# Altium schematic sheet Power_supply.SchDoc
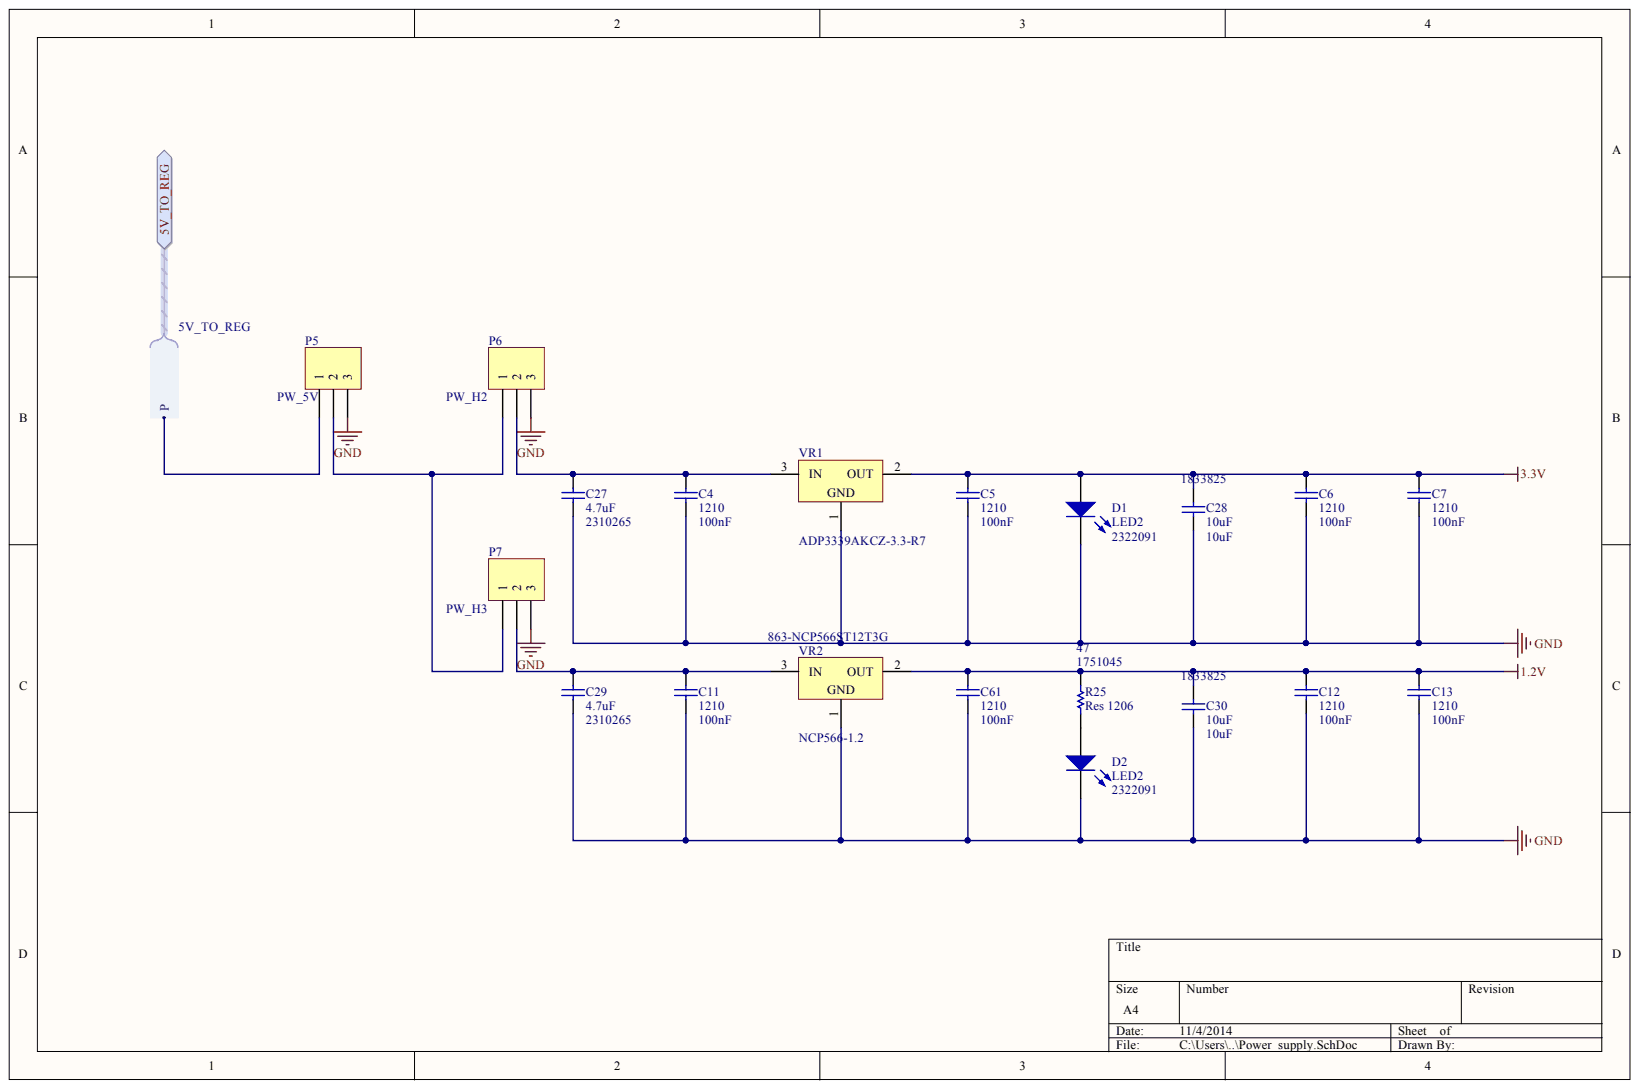
[diagram p0: Power_supply.SchDoc]

## Parts
C4  1210  value 100nF  [CAPC1210(3225)105_L]
C5  1210  value 100nF  [CAPC1210(3225)105_L]
C6  1210  value 100nF  [CAPC1210(3225)105_L]
C7  1210  value 100nF  [CAPC1210(3225)105_L]
C11  1210  value 100nF  [CAPC1210(3225)105_L]
C12  1210  value 100nF  [CAPC1210(3225)105_L]
C13  1210  value 100nF  [CAPC1210(3225)105_L]
C27  4.7uF  Supplier Part Number 1: 2310265  [C1206]
C28  10uF  Supplier Part Number 1: 1833825  [C1206]
C29  4.7uF  Supplier Part Number 1: 2310265  [C1206]
C30  10uF  Supplier Part Number 1: 1833825  [C1206]
C61  1210  value 100nF  [CAPC1210(3225)105_L]
D1  LED2  Supplier Part Number 1: 2322091  [C1206]
D2  LED2  Supplier Part Number 1: 2322091  [C1206]
P5  PW_5V  [HDR1X3]
P6  PW_H2  [HDR1X3]
P7  PW_H3  [HDR1X3]
R25  Res 1206  Case-EIA: 1206  Supplier Part Number 1: 1751045  [RESC1206(3216)_L]
VR1  ADP3339AKCZ-3.3-R7  [ADI-KC-3_M]
VR2  NCP566-1.2  Supplier Part Number 1: 863-NCP566ST12T3G  [SOT223_N]

## Ports
5V_TO_REG, 5V_TO_REG.P

## Nets
1.2V: C12.2 C13.2 C30.2 C61.2 R25.1 VR2.2
3.3V: C5.2 C6.2 C7.2 C28.2 D1.1 VR1.2
GND: C4.1 C5.1 C6.1 C7.1 C11.1 C12.1 C13.1 C27.1 C28.1 C29.1 C30.1 C61.1 D1.2 D2.2 P5.3 P6.3 P7.3 VR1.1 VR2.1
5V_TO_REG: P5.1 ; port 5V_TO_REG
NetC4_2: C4.2 C27.2 P6.2 VR1.3
NetC11_2: C11.2 C29.2 P7.2 VR2.3
NetD2_1: D2.1 R25.2
NetP5_2: P5.2 P6.1 P7.1

## Library data of the parts
C4-C7 C11-C13 C61 = CMP-1038-01663-1: CAP 100nF 100V ±5% 1210 (3225 Metric) Thickness 1.05mm SMD; Case-Metric: 3225; Case-EIA: 1210; Component Kind: Standard; Library Name: Altium Content Vault; Max Thickness: 1.05 mm; Rated Voltage: 100 V; Technology: SMT; Tolerance: ±5%
C27 C29 = Cap Semi: Capacitor (Semiconductor SIM Model); Manufacturer: AVX; Manufacturer Part Number: 12061Z475KAT2A; Capacitance: 4.7; Capacitance Tolerance: ± 10%; Capacitor Case Style: 1206 [3216 Metric]; Capacitor Terminals: SMD<!--FANDB--><div class="features-and-benefits-container"></div>; Dielectric Characteristic: X7; SVHC: No SVHC (16-Jun-2014); Value: 100pF; Voltage Rating: 100; Capacitance Tolerance ±: ± 10%; Ceramic Capacitor Case: 1206 [3216 Metric]; Component Kind: Standard; ComponentLink1Description: http://www.farnell.com/datasheets/1789384.pdf; ComponentLink1URL: http://www.farnell.com/datasheets/1789384.pdf; LatestRevisionDate: 29-May-2009; LatestRevisionNote: IPC-7351 Footprint Added.; Library Name: Miscellaneous Devices.IntLib; MSL: MSL 1 - Unlimited; Operating Temperature Max: 125; Operating Temperature Min: -55; PackageDescription: Chip Capacitor; PackageReference: C1206; Packaging: Cut Tape; Pricing 1: 1=6,85, 50=6,2, 100=4,8, 1000=3,85, 2000=2,45 (EUR); Product Range: -; Published: 8-Jun-2000; RoHS: YES; Signal Integrity: Cap Semi; Simulation: CAP; Stock 1: 213; Supplier 1: Farnell; Publisher: Altium Limited
C28 C30 = Cap Semi: Capacitor (Semiconductor SIM Model); Manufacturer: AVX; Manufacturer Part Number: 12063D106KAT2A; Capacitance: 10; Capacitance Tolerance: ± 10%; Capacitor Case Style: 1206 [3216 Metric]; Capacitor Terminals: SMD; Dielectric Characteristic: X5; SVHC: No SVHC (16-Dec-2013); Voltage Rating: 25; Capacitance Tolerance ±: ± 10%; Ceramic Capacitor Case: 1206 [3216 Metric]; Component Kind: Standard; ComponentLink1Description: http://www.farnell.com/datasheets/1796776.pdf; ComponentLink1URL: http://www.farnell.com/datasheets/1796776.pdf; LatestRevisionDate: 29-May-2009; LatestRevisionNote: IPC-7351 Footprint Added.; Library Name: Miscellaneous Devices.IntLib; MSL: MSL 1 - Unlimited; Operating Temperature Max: 85; Operating Temperature Min: -55; Operating Temperature Range: -55°C to +85; PackageDescription: Chip Capacitor; PackageReference: C1206; Packaging: Cut Tape; Pricing 1: 10=3.58, 100=2.42, 500=1.21, 1000=1, 2000=0.8 (EUR); Product Range: -; Published: 8-Jun-2000; RoHS: YES; Series: Hi-Cap MLCC<!--FANDB--><div class="features-and-benefits-container"></div>; Signal Integrity: Cap Semi; Simulation: CAP; Stock 1: 16889; Supplier 1: Farnell; Value: 10uF; Publisher: Altium Limited
D1 D2 = LED2: Typical RED, GREEN, YELLOW, AMBER GaAs LED; Manufacturer: WURTH ELEKTRONIK; Manufacturer Part Number: 156120GS75300; Component Kind: Standard; ComponentLink1Description: http://katalog.we-online.de/led/datasheet/156120GS75300.pdf; ComponentLink1URL: http://katalog.we-online.de/led/datasheet/156120GS75300.pdf; LatestRevisionDate: 17-Jul-2002; LatestRevisionNote: Re-released for DXP Platform.; Library Name: Miscellaneous Devices.IntLib; PackageDescription: SM LED; 2 Flat Leads; PackageReference: 3.2X1.6X1.1; PackageVersion: Feb-2002; Pricing 1: 1=5,3, 50=4,9, 100=4,6, 500=3,65, 2000=3,3, 5000=2,95, 5000=2,65, 30000=2,4 (EUR); Published: 8-Jun-2000; RoHS: YES; Simulation: LED2; Stock 1: 15358; Supplier 1: Farnell; Publisher: Altium Limited
P5-P7 = Header 3: Header, 3-Pin; Component Kind: Standard; LatestRevisionDate: 17-Jul-2002; LatestRevisionNote: Re-released for DXP Platform.; Library Name: Miscellaneous Connectors.IntLib; Signal Integrity: Connector; Publisher: Altium Limited
R25 = CMP-1014-00056-1: 105R 0.25W 5% 1206 (3216 Metric)  SMD; Manufacturer: PANASONIC; Manufacturer Part Number: ERJB2AF470V; Case-Metric: 3216; External Depth: 1.6; External Length / Height: 0.65; External Width: 3.2; No. of Pins: 2; Overload Voltage: 400; Power Rating: 0.5; Product Range: PANASONIC - ERJ Wide Terminal Series; Reel Quantity: 5000; Resistance: 47; Resistance Tolerance: ± 1%; Resistor Case Style: 1206 Wide; Resistor Element Material: Thick Film; SVHC: No SVHC (16-Dec-2013); Temperature Coefficient: ± 100; Voltage Rating: 200; Component Kind: Standard; ComponentLink1Description: http://www.farnell.com/datasheets/436795.pdf; ComponentLink1URL: http://www.farnell.com/datasheets/436795.pdf; ComponentLink2Description: http://www.farnell.com/datasheets/1718548.pdf; ComponentLink2URL: http://www.farnell.com/datasheets/1718548.pdf; ComponentLink3Description: http://www.farnell.com/cad/1697905.zip; ComponentLink3URL: http://www.farnell.com/cad/1697905.zip; Library Name: Altium Content Vault; MSL: -; Operating Temperature Max: 155; Operating Temperature Min: -55; Operating Temperature Range: -55°C to +155; Packaging: Cut Tape; Power: 0.25 W; Pricing 1: 5=1,83, 50=1,71, 100=1,61, 500=1,52, 5000=1,44 (EUR); Resistor Mounting: SMD; RoHS: YES; Series: ERJ<!--FANDB--><div class="features-and-benefits-container"></div>; Stock 1: 185; Supplier 1: Farnell; Technology: SMT; Tolerance: 5 %; Value: 105R
VR1 = CMP-0786-00156-1: High Accuracy, Ultralow IQ, 1.5 A, anyCAP Low Dropout Regulator, 2.8 to 6 V Vin, 3.3 V Vout, Industrial, 3-pin SOT223 (KC-3), Reel; Manufacturer: Analog Devices; Iout max: 1.5A; Preset Vout Values (V): 3.3; Supply Current IGND @ Small Load: 130uA; Vin (max) (V): 6; Vin (min) (V): 2.8; Code_JEDEC: TO-261-AA; Component Kind: Standard; ComponentLink1Description: Manufacturer URL; ComponentLink1URL: http://www.analog.com/en/index.html; ComponentLink2Description: Datasheet; ComponentLink2URL: http://www.analog.com/static/imported-files/data_sheets/ADP3339.pdf; ComponentLink3Description: Package Specification; ComponentLink3URL: http:/www.analog.com/static/imported-files/packages/PKG_PDF/SOT_223(KC)/KC_3.pdf; ComponentLink4Description: URL Link1; ComponentLink4URL: Product URL:http://www.analog.com/en/ADP3339/productSearch.html; DatasheetVersion: Rev. C; Library Name: Altium Content Vault; Mounting Technology: Surface Mount; PackageDescription: 3-Pin Small Outline Transistor Package, Body 3.5 x 6.5 mm, Pitch 2.3 mm; PackageReference: KC-3; PackageVersion: 11-20-2012-B, 11/2012; Packing: Reel; PartNumber: ADP3339AKCZ-3.3-R7
VR2 = LM1084IT-3.3: 5A Low Dropout Positive Regulators, 3-pin TO-220; Manufacturer Part Number: NCP566ST12T3G; Brand: ON Semiconductor; Dropout Voltage - Max: 1.3 V at 1.5 mA; Input Voltage MAX: 9 V; Line Regulation: 0.02 %; Load Regulation: 0.04 %; Number of Outputs: 1 Output; Output Current: 1.5 A; Output Type: Fixed; Output Voltage: 1.2 V; Reference Voltage: 0.9 V; Voltage Regulation Accuracy: 3 %; Category: LDO Voltage Regulators; Component Kind: Standard; ComponentLink1Description: http://www.farnell.com/datasheets/115299.pdf; ComponentLink1URL: http://www.farnell.com/datasheets/115299.pdf; Library Name: NSC LDO.IntLib; Manufacturer: ON Semiconductor; Maximum Operating Temperature: + 125 C; Minimum Operating Temperature: - 40 C; Minimum Order Quantity: 1; Mounting Style: SMD/SMT; Package / Case: SOT-223; Packaging: Reel; Pricing 1: 1=1.42, 10=1.13, 100=0.871, 500=0.770, 1000=0.608, 4000=0.539, 8000=0.518, 24000=0.502 (AUD); Product Category: Amplifiers- Audio; Reeling: True; RoHS: RoHS Compliant; RoHS - Mouser: Y; Series: NCP566; Standard Pack Qty: 4000; Stock 1: 3461; Supplier 1: Mouser
